# Altium schematic sheet Flash.SchDoc
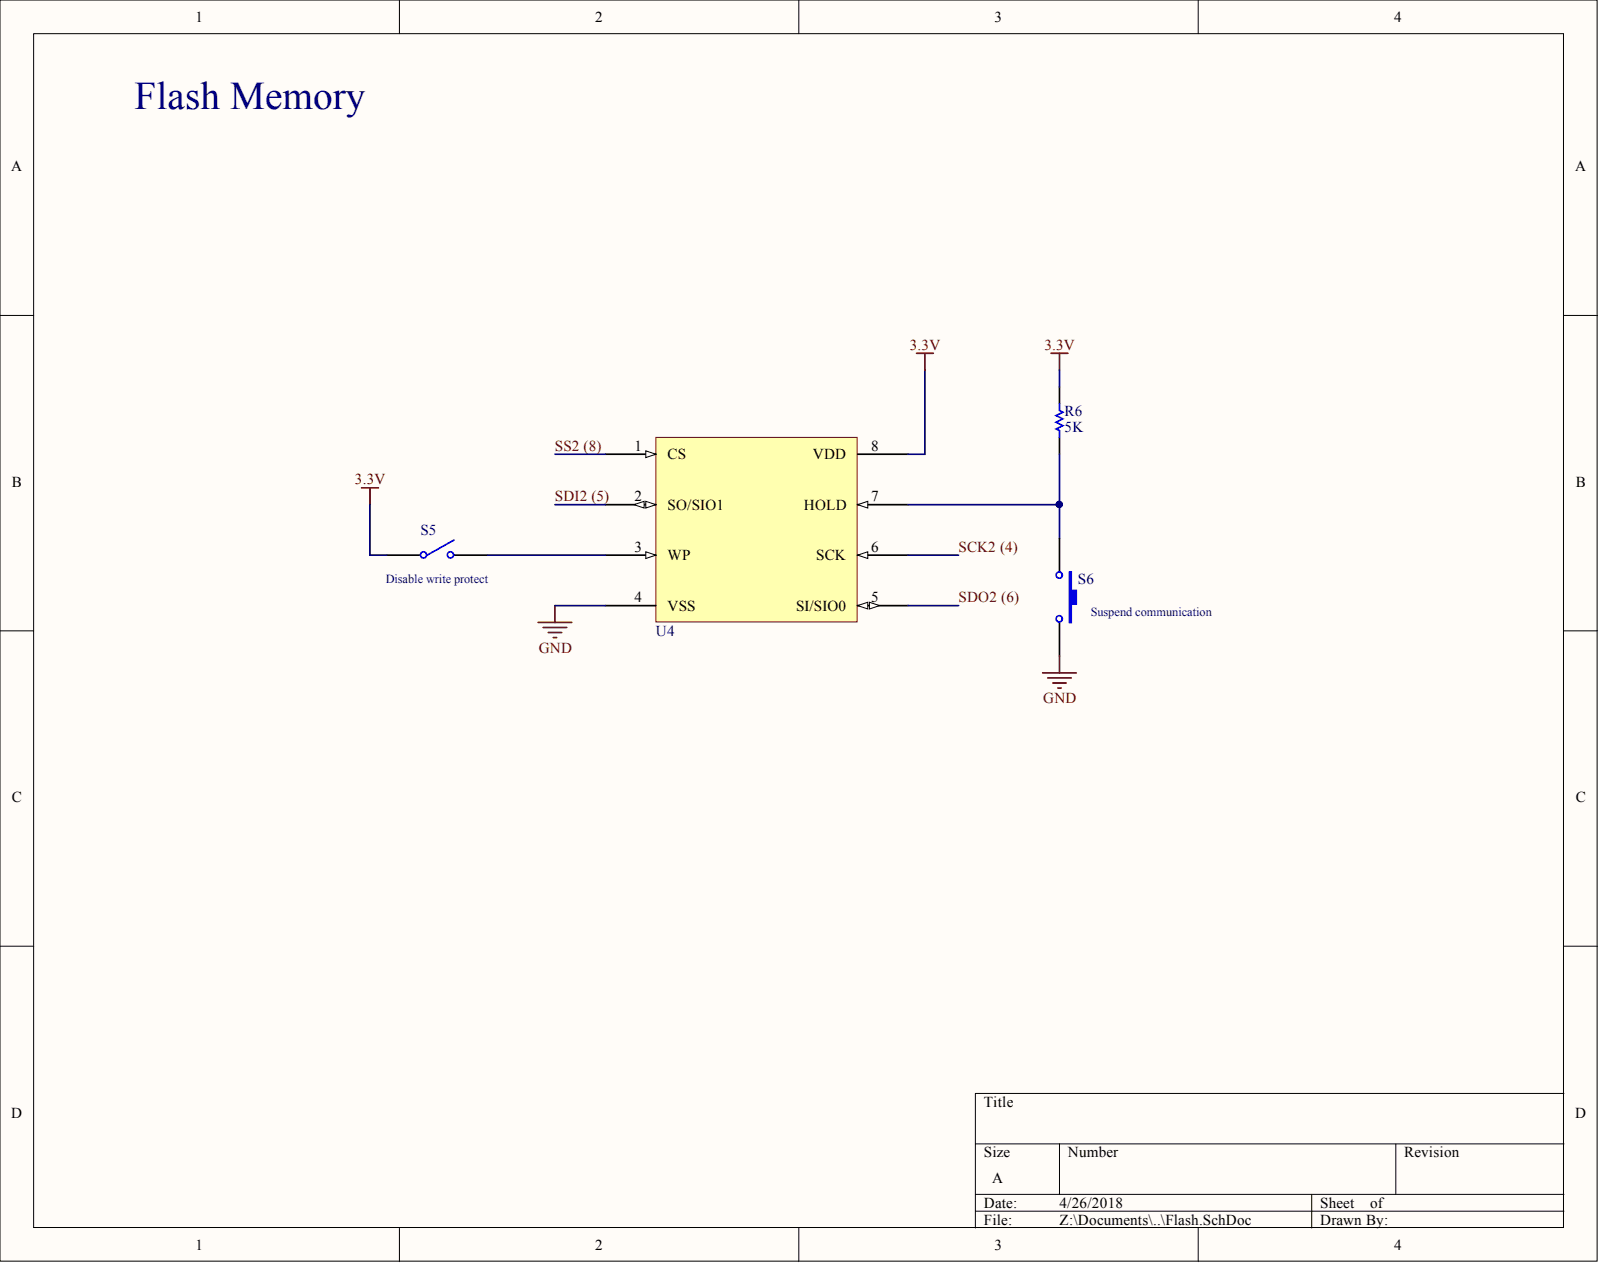
[diagram p0: Flash.SchDoc]

## Parts
R6  5K  [AXIAL-0.3]
S5  Single-Pole, Single-Throw Switch  [SPST-2]  (value not drawn)
S6  Switch  [SPST-2]  (value not drawn)
U4  LE25U40CMD  (value not drawn)

## Nets
3.3V: R6.2 S5.1 U4.8(VDD)
GND: S6.2 U4.4(VSS)
SCK2 (4): U4.6(SCK)
SDI2 (5): U4.2(SO/SIO1)
SDO2 (6): U4.5(SI/SIO0)
SS2 (8): U4.1(CS)
NetR6_1: R6.1 S6.1 U4.7(HOLD)
NetS5_2: S5.2 U4.3(WP)

## Library data of the parts
R6 = Res1: Resistor; Component Kind: Standard; LatestRevisionDate: 17-Jul-2002; LatestRevisionNote: Re-released for DXP Platform.; Library Name: Miscellaneous Devices.IntLib; PackageDescription: Axial Device, Thru-Hole; 2 Leads; 0.3 in Pin Spacing; PackageReference: AXIAL-0.3; Published: 8-Jun-2000; Signal Integrity: Res; Simulation: RESISTOR; Value: 5K; Publisher: Altium Limited
S5 = SW-SPST: Disable write protect; Component Kind: Standard; LatestRevisionDate: 17-Jul-2002; LatestRevisionNote: Re-released for DXP Platform.; Library Name: Miscellaneous Devices.IntLib; PackageDescription: Switch; 2 Leads; PackageReference: SPST-2; Published: 24-Mar-1999; Publisher: Altium Limited
S6 = SW-PB: Suspend communication; Component Kind: Standard; LatestRevisionDate: 17-Jul-2002; LatestRevisionNote: Re-released for DXP Platform.; Library Name: Miscellaneous Devices.IntLib; PackageDescription: Switch; 2 Leads; PackageReference: SPST-2; Published: 24-Mar-1999; Publisher: Altium Limited
U4 = LE25U40CMD: Flash memory; Fabricant: On Semiconductor; Fournisseur: Farnell; RefFabricant: LE25U40CMDTWG; RefFournisseur: 2627898; Component Kind: Standard; Library Name: Schlib.SchLib
